# Altium schematic sheet Test Board.SchDoc
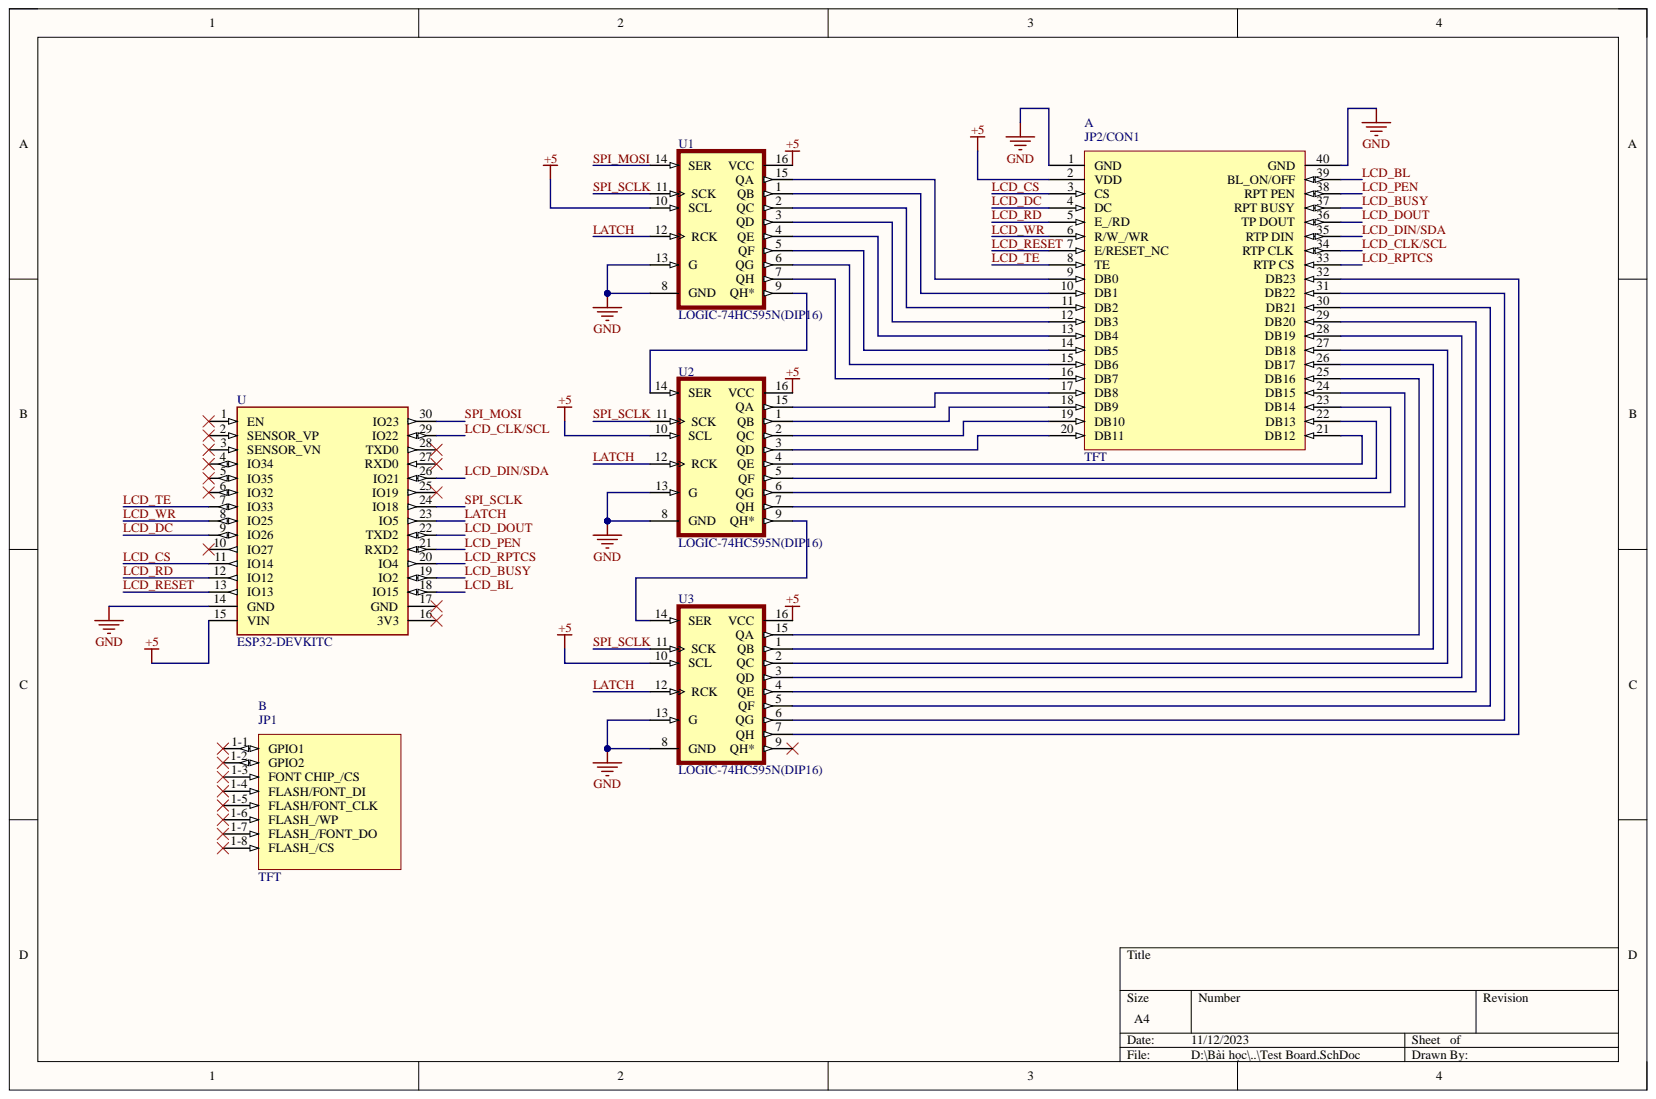
[diagram p0: Test Board.SchDoc]

## Parts
A  TFT  [Female Header 20x2]
B  TFT  [Female Header 20x2]
U  ESP32-DEVKITC  [MODULE_ESP32-DEVKITC]
U1  LOGIC-74HC595N(DIP16)  [SO16]
U2  LOGIC-74HC595N(DIP16)  [SO16]
U3  LOGIC-74HC595N(DIP16)  [SO16]

## Nets
+5: A.2(VDD) U.15(VIN) U1.10(SCL) U1.16(VCC) U2.10(SCL) U2.16(VCC) U3.10(SCL) U3.16(VCC)
GND: A.1(GND) A.40(GND) U.14(GND) U1.8(GND) U1.13(G) U2.8(GND) U2.13(G) U3.8(GND) U3.13(G)
Net_1-1: B.1(GPIO1)
Net_1-2: B.2(GPIO2)
Net_1-3: B.3(FONT_CHIP_/CS)
Net_1-4: B.4(FLASH/FONT_DI)
Net_1-5: B.5(FLASH/FONT_CLK)
Net_1-6: B.6(FLASH_/WP)
Net_1-7: B.7(FLASH_/FONT_DO)
Net_1-8: B.8(FLASH_/CS)
Net_9: A.9(DB0) U1.15(QA)
Net_10: A.10(DB1) U1.1(QB)
Net_11: A.11(DB2) U1.2(QC)
Net_12: A.12(DB3) U1.3(QD)
Net_13: A.13(DB4) U1.4(QE)
Net_14: A.14(DB5) U1.5(QF)
Net_15: A.15(DB6) U1.6(QG)
Net_16: A.16(DB7) U1.7(QH)
Net_17: A.17(DB8) U2.15(QA)
Net_18: A.18(DB9) U2.1(QB)
Net_19: A.19(DB10) U2.2(QC)
Net_20: A.20(DB11) U2.3(QD)
Net_21: A.21(DB12) U2.4(QE)
Net_22: A.22(DB13) U2.5(QF)
Net_23: A.23(DB14) U2.6(QG)
Net_24: A.24(DB15) U2.7(QH)
Net_25: A.25(DB16) U3.15(QA)
Net_26: A.26(DB17) U3.1(QB)
Net_27: A.27(DB18) U3.2(QC)
Net_28: A.28(DB19) U3.3(QD)
Net_29: A.29(DB20) U3.4(QE)
Net_30: A.30(DB21) U3.5(QF)
Net_31: A.31(DB22) U3.6(QG)
Net_32: A.32(DB23) U3.7(QH)
LATCH: U.23(IO5) U1.12(RCK) U2.12(RCK) U3.12(RCK)
LCD_BL: A.39(BL_ON/OFF) U.18(IO15)
LCD_BUSY: A.37(RPT_BUSY) U.19(IO2)
LCD_CLK/SCL: A.34(RTP_CLK) U.29(IO22)
LCD_CS: A.3(CS) U.11(IO14)
LCD_DC: A.4(DC) U.9(IO26)
LCD_DIN/SDA: A.35(RTP_DIN) U.26(IO21)
LCD_DOUT: A.36(TP_DOUT) U.22(TXD2)
LCD_PEN: A.38(RPT_PEN) U.21(RXD2)
LCD_RD: A.5(E_/RD) U.12(IO12)
LCD_RESET: A.7(E/RESET_NC) U.13(IO13)
LCD_RPTCS: A.33(RTP_CS) U.20(IO4)
LCD_TE: A.8(TE) U.7(IO33)
LCD_WR: A.6(R/W_/WR) U.8(IO25)
SPI_MOSI: U.30(IO23) U1.14(SER)
SPI_SCLK: U.24(IO18) U1.11(SCK) U2.11(SCK) U3.11(SCK)
NetU1_9: U1.9(QH*) U2.14(SER)
NetU2_9: U2.9(QH*) U3.14(SER)

Not connected: U 1(EN) 2(SENSOR_VP) 3(SENSOR_VN) 4(IO34) 5(IO35) 6(IO32) 10(IO27) 16(3V3) 17(GND) 25(IO19) 27(RXD0) 28(TXD0); U3 9(QH*)

## Library data of the parts
U = ESP32-DEVKITC: - Transceiver; 802.11 b/g/n _Wi-Fi, WiFi, WLAN_, BluetoothÂ® Smart Ready 4.x Dual Mode For Use With ESP-WROOM-32; MF: Espressif Systems; MP: ESP32-DEVKITC-32D-F; Availability: Unavailable; Component Kind: Standard; Library Name: TestBoardLib.SchLib; Package: None; Price: None; SnapEDA_Link: https://www.snapeda.com/parts/ESP32-DEVKITC-32D-F/Espressif%20Systems/view-part/4220172/?ref=snap
U1-U3 = LOGIC-74HC595N(DIP16): Shift Shift Register 1 Element 8 Bit 16-PDIP; MF: Texas Instruments; Availability: In Stock; Check_prices: https://www.snapeda.com/parts/74HC595N/Texas+Instruments/view-part/?ref=eda; Component Kind: Standard; Library Name: TestBoardLib.SchLib; MP: 74HC595N; Package: SOT38-4-16 Nexperia; Price: None; Purchase-URL: https://pricing.snapeda.com/search/part/74HC595N/?ref=eda; SnapEDA_Link: https://www.snapeda.com/parts/74HC595N/Texas+Instruments/view-part/?ref=snap
A B = TFT: Component Kind: Standard; Library Name: TestBoardLib.SchLib
